# Altium schematic sheet payloadSheet2.SchDoc(payloadSheet2)
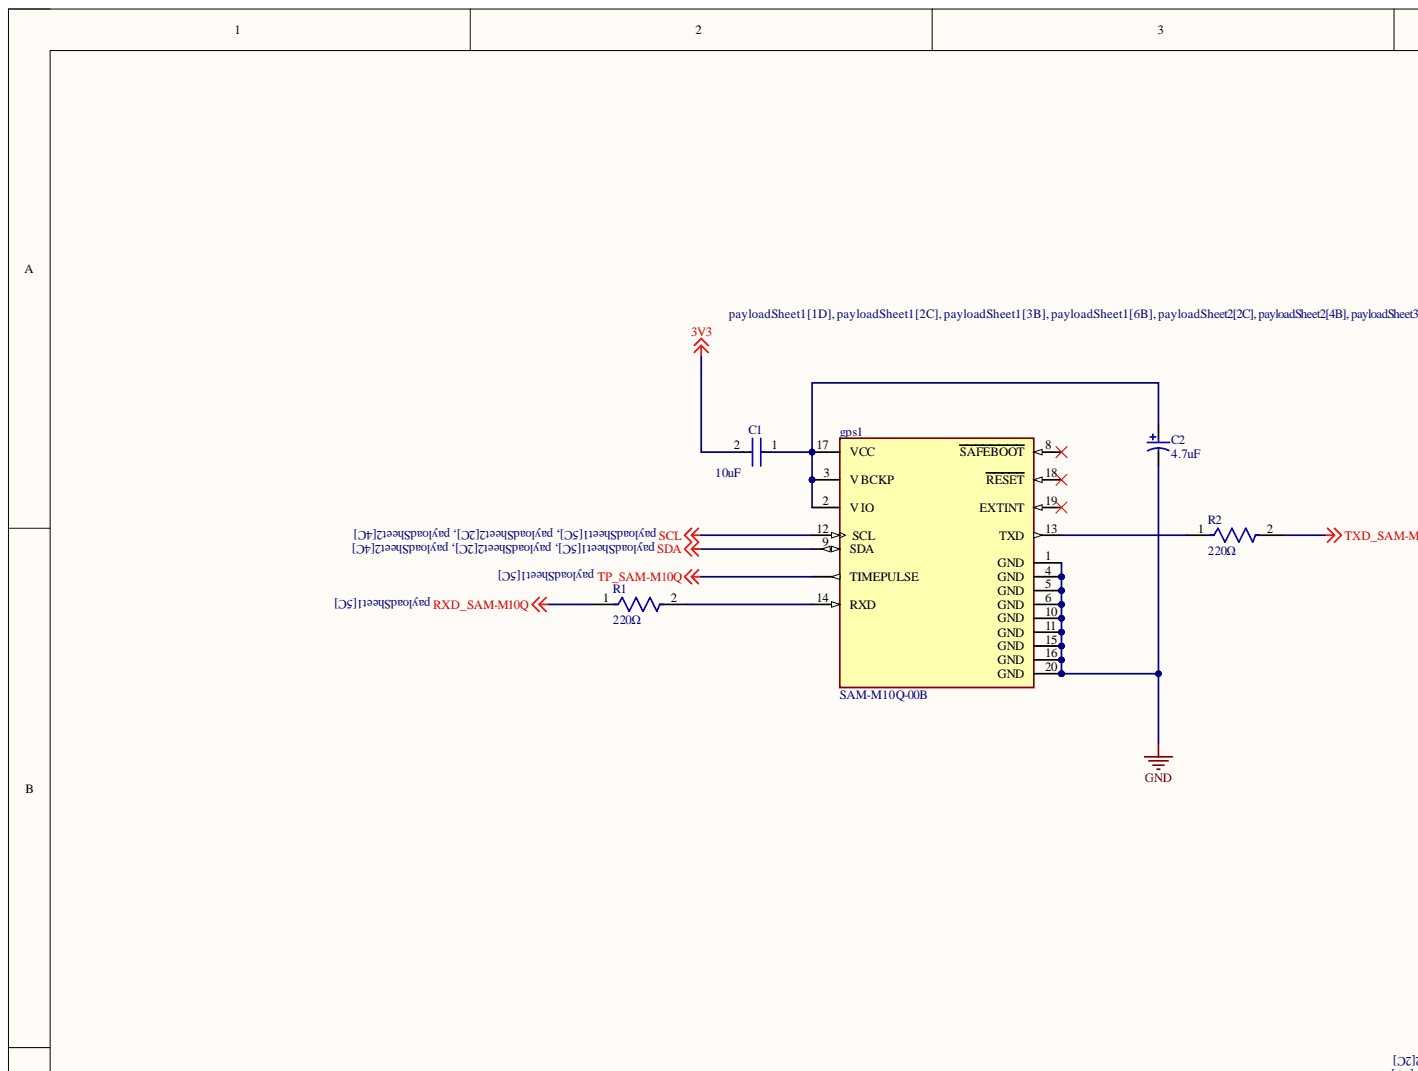
[diagram p0: payloadSheet2.SchDoc, top left]
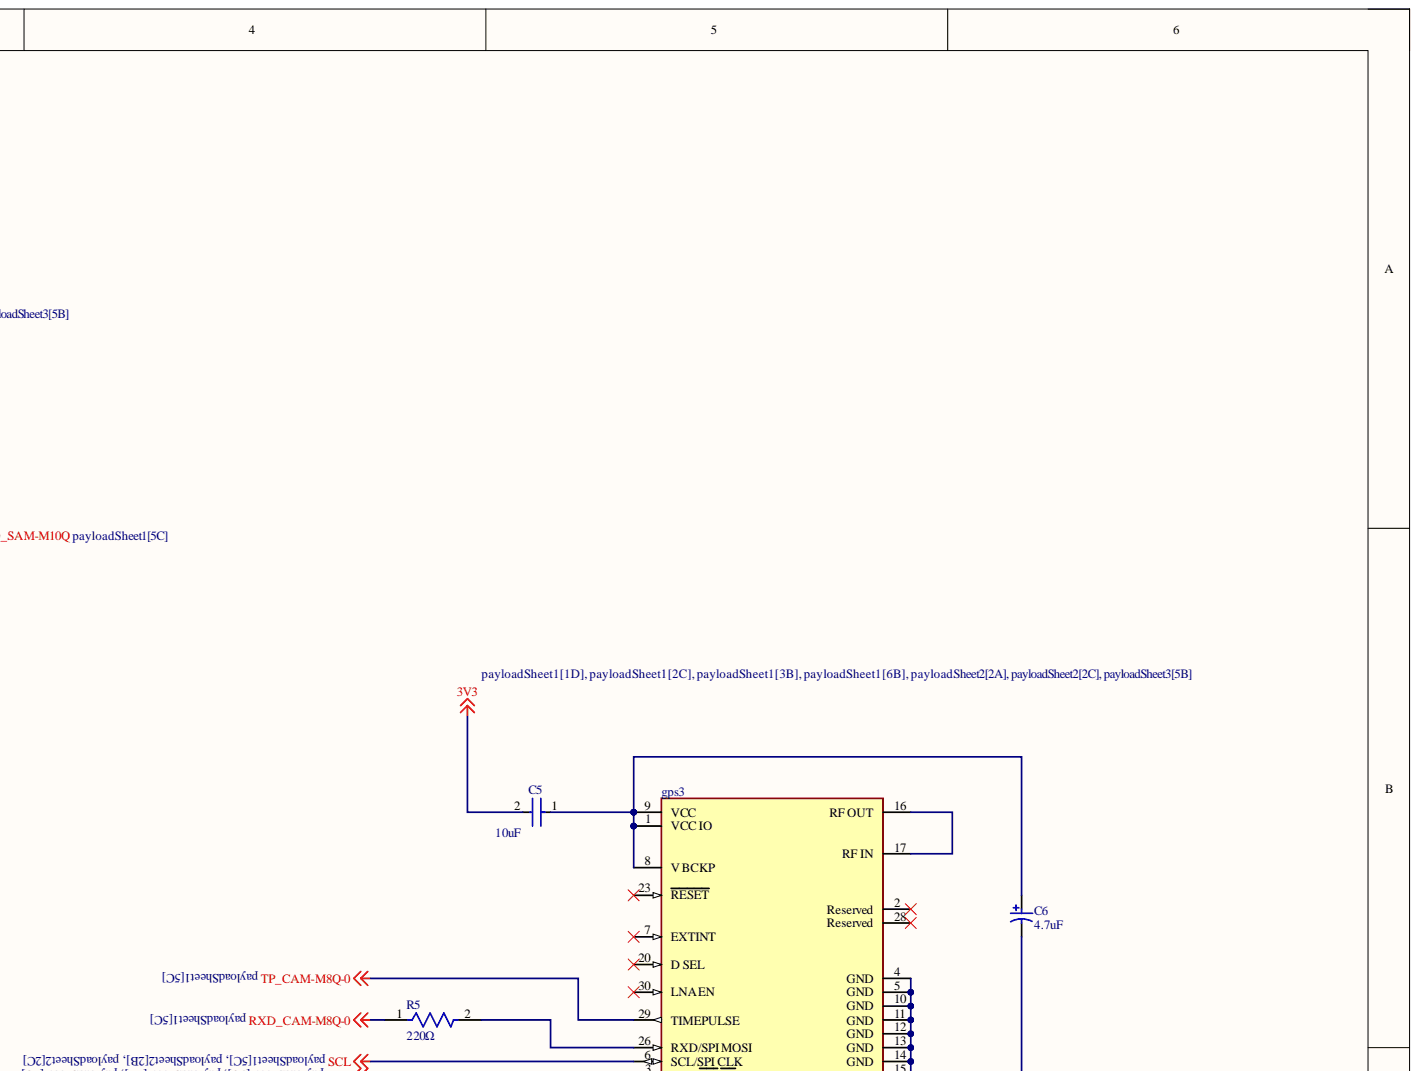
[diagram p1: payloadSheet2.SchDoc, top right]
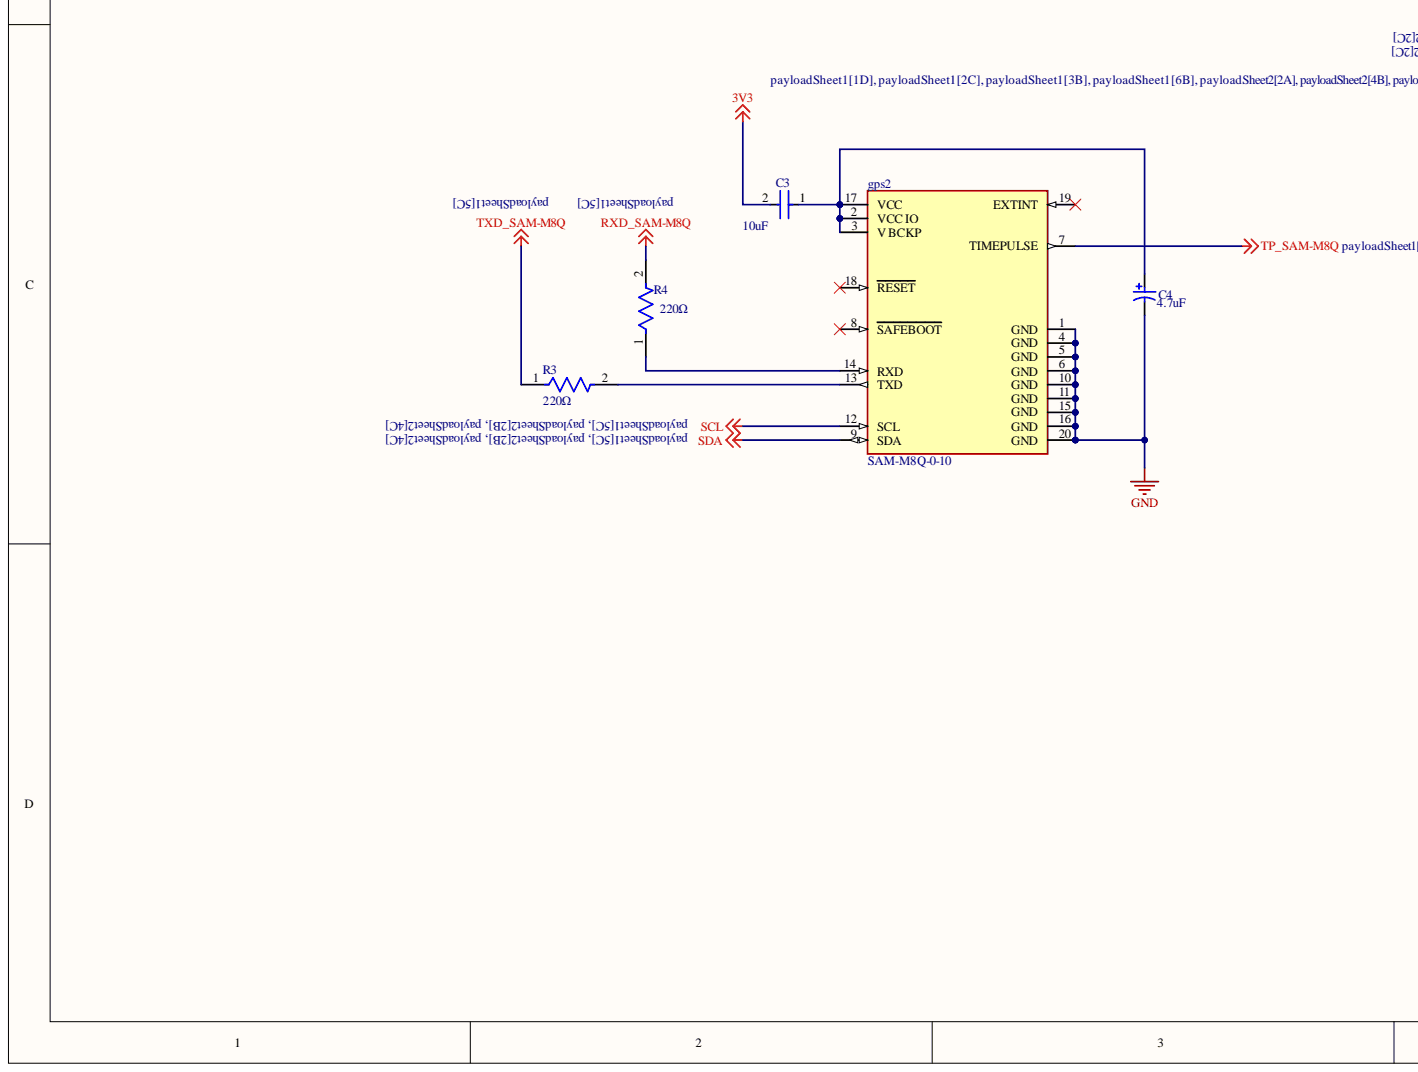
[diagram p2: payloadSheet2.SchDoc, bottom left]
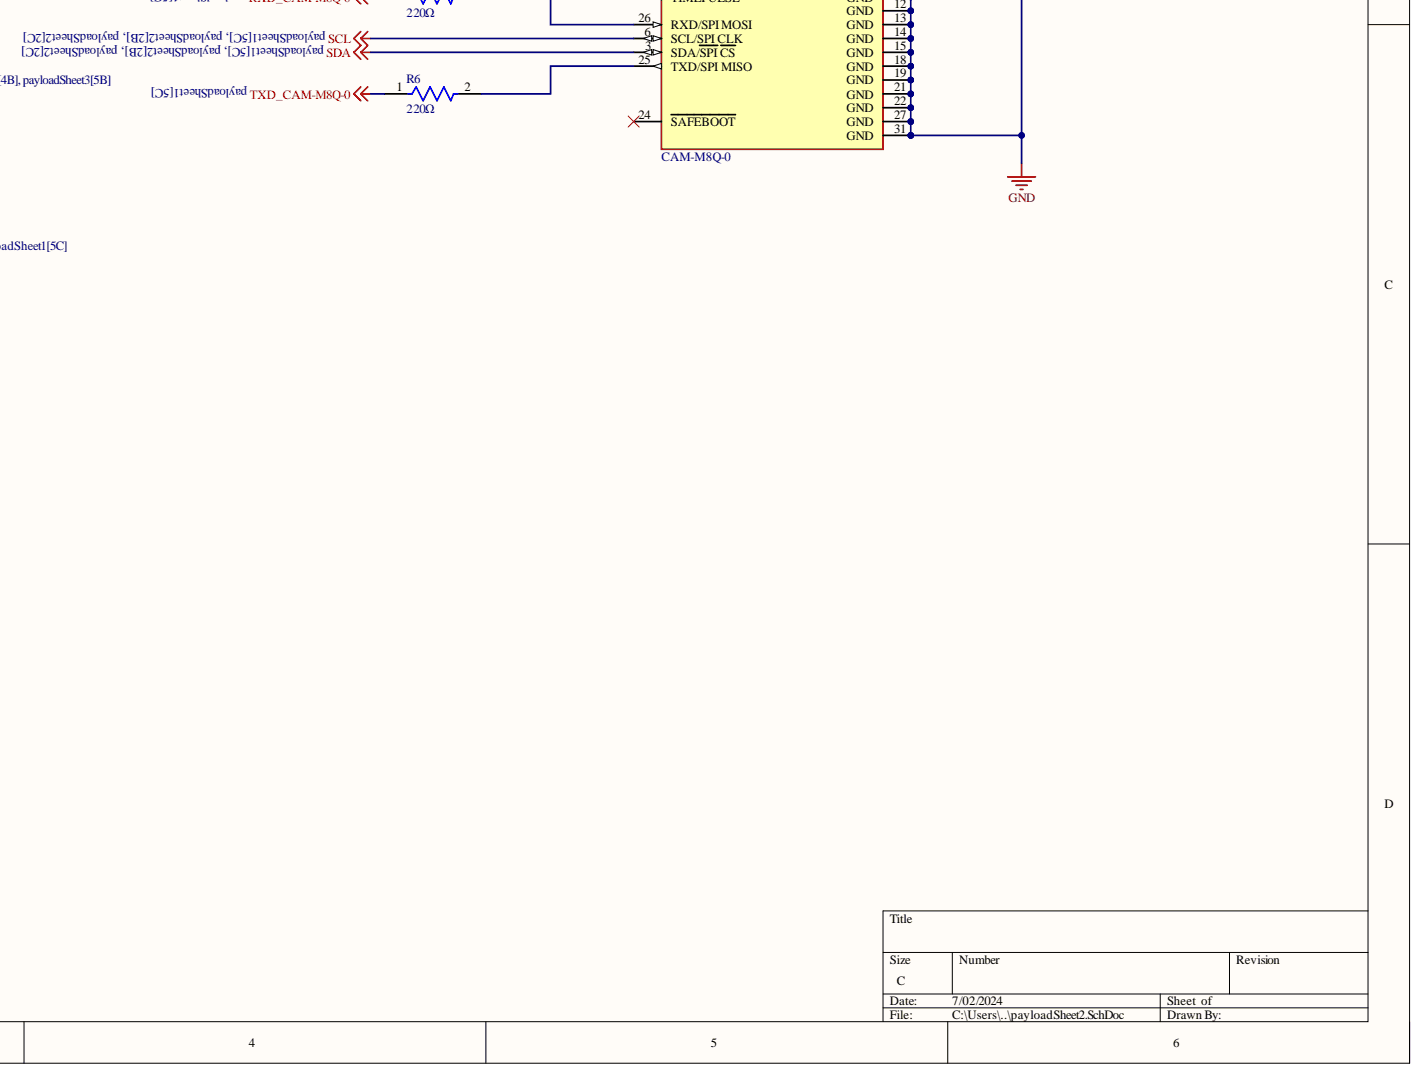
[diagram p3: payloadSheet2.SchDoc, bottom right]

## Parts
C1  10uF  [FP-RCE3DB-MFG]
C2  4.7uF  [AVX-TAP475K016SCS]
C3  10uF  [FP-RCE3DB-MFG]
C4  4.7uF  [AVX-TAP475K016SCS]
C5  10uF  [FP-RCE3DB-MFG]
C6  4.7uF  [AVX-TAP475K016SCS]
gps1  SAM-M10Q-00B  [FP-SAM-M10Q-00B-MFG]
gps2  SAM-M8Q-0-10  [FP-SAM-M8Q-0-10-MFG]
gps3  CAM-M8Q-0  [FP-CAM-M8Q-0-MFG]
R1  220Ω  [FP-MBA0204-MFG]
R2  220Ω  [FP-MBA0204-MFG]
R3  220Ω  [FP-MBA0204-MFG]
R4  220Ω  [FP-MBA0204-MFG]
R5  220Ω  [FP-MBA0204-MFG]
R6  220Ω  [FP-MBA0204-MFG]

## Nets
3V3: C1.2 C3.2 C5.2
GND: C2.2 C4.2 C6.2 gps1.1(GND) gps1.4(GND) gps1.5(GND) gps1.6(GND) gps1.10(GND) gps1.11(GND) gps1.15(GND) gps1.16(GND) gps1.20(GND) gps2.1(GND) gps2.4(GND) gps2.5(GND) gps2.6(GND) gps2.10(GND) gps2.11(GND) gps2.15(GND) gps2.16(GND) gps2.20(GND) gps3.4(GND) gps3.5(GND) gps3.10(GND) gps3.11(GND) gps3.12(GND) gps3.13(GND) gps3.14(GND) gps3.15(GND) gps3.18(GND) gps3.19(GND) gps3.21(GND) gps3.22(GND) gps3.27(GND) gps3.31(GND)
RXD_CAM-M8Q-0: R5.1
RXD_SAM-M8Q: R4.2
RXD_SAM-M10Q: R1.1
SCL: gps1.12(SCL) gps2.12(SCL) gps3.6(SCL/SPI_CLK)
SDA: gps1.9(SDA) gps2.9(SDA) gps3.3(SDA/SPI_CS)
TP_CAM-M8Q-0: gps3.29(TIMEPULSE)
TP_SAM-M8Q: gps2.7(TIMEPULSE)
TP_SAM-M10Q: gps1.7(TIMEPULSE)
TXD_CAM-M8Q-0: R6.1
TXD_SAM-M8Q: R3.1
TXD_SAM-M10Q: R2.2
NetC1_1: C1.1 C2.1 gps1.2(V_IO) gps1.3(V_BCKP) gps1.17(VCC)
NetC3_1: C3.1 C4.1 gps2.2(VCC_IO) gps2.3(V_BCKP) gps2.17(VCC)
NetC5_1: C5.1 C6.1 gps3.1(VCC_IO) gps3.8(V_BCKP) gps3.9(VCC)
Netgps1_13: gps1.13(TXD) R2.1
Netgps1_14: gps1.14(RXD) R1.2
Netgps2_13: gps2.13(TXD) R3.2
Netgps2_14: gps2.14(RXD) R4.1
Netgps3_16: gps3.16(RF_OUT) gps3.17(RF_IN)
Netgps3_25: gps3.25(TXD/SPI_MISO) R6.2
Netgps3_26: gps3.26(RXD/SPI_MOSI) R5.2

Not connected: gps1 8(SAFEBOOT) 18(RESET) 19(EXTINT); gps2 8(SAFEBOOT) 18(RESET) 19(EXTINT); gps3 2(Reserved) 7(EXTINT) 20(D_SEL) 23(RESET) 24(SAFEBOOT) 28(Reserved) 30(LNA_EN)

## Library data of the parts
C1 C3 C5 = CMP-06035-033894-1: Cap Ceramic 10uF 25V X7R ±10% Radial 2.5mm +125°C Automotive Bulk; Lead Diameter: 0.5mm; Lead/Base Style: Straight; Capacitance: 10uF; Case/Package: Radial; Component Kind: Standard; Dielectric: X7R; Height: 5mm; Height - Seated (Max): 0.197inch; Lead Pitch: 0.098inch; Length: 0.217inch; Library Name: Altium Content Vault; Max Operating Temperature: 125°C; Min Operating Temperature: -55°C; Mount: Through Hole; Package Quantity: 500; Packaging: Bulk; Ratings: AEC-Q200; Resistor Type: Ceramic; Termination: Radial; Tolerance: 10%; Voltage Rating: 25V; Voltage Rating (DC): 25V; Width: 0.157inch
C2 C4 C6 = CMP-2000-07562-1: Dipped Radial Capacitor, 4.7 uF, +/- 10%, 16 V, -55 to 125 degC, RoHS, Bulk; Manufacturer: AVX; Dielectric Material: Tantalum; Dissipation Factor: 6%; ESR (Equivalent Series Resistance): 5Ohm; Lead Diameter: 0.5mm; Leakage Current: 600nA; Life (Hours): 1000Hour; Polarity: Polar; Voltage: 16V; Capacitance: 4.7uF; Case\/Package: Dipped; Component Kind: Standard; Datasheet URL: http://datasheets.avx.com/tap.pdf; Datasheet Version: 08/2015; Diameter: 4.5mm; Height: 7.5mm; Height - Seated (Max): 0.295inch; Lead Free: LeadFree; Lead Pitch: 2.5mm; Length: 7.5mm; Library Name: Altium Content Vault; Manufacturer URL: http://www.avx.com/; Max Operating Temperature: 125degC; Min Operating Temperature: -55degC; Mount: PCB; Mounting Technology: ThroughHole; Number of Pins: 2; Package Description: 2-Pin Through Hole Device, Dia 4.5 mm, Height 7.5 mm; Package Quantity: 1000; Package Reference: TAP475K016SCS; Packaging: Bulk; Radiation Hardening: No; REACH SVHC: NoSVHC; RoHSCompliant: True; Termination: Radial; Tolerance: 10%; Voltage Rating: 16V; Voltage Rating (DC): 16V
gps1 = CMP-207130-000027-1: M10 GNSS LGA PATCH ANTENNA MOD; Component Kind: Standard; Library Name: Altium Content Vault
gps2 = CMP-207130-000004-3: RF RX GALILEO 1.575GHZ/1.602GHZ; Manufacturer: uBlox; Automotive Grade: Grade 3; Data Rate: 400kbits/s; Interface: I2C,UART,Other; Max Frequency: 1602MHz; Max Supply Voltage: 3.6V; Min Supply Voltage: 2.7V; Nominal Supply Current: 9.5-32mA; Sensitivity: -165dBm; Automotive: Yes; Category: IC; Component Kind: Standard; ComponentLink2Description: Datasheet; ComponentLink2URL: https://www.u-blox.com/sites/default/files/SAM-M8Q_DataSheet_%28UBX-16012619%29.pdf; Device Class L1: Integrated Circuits (ICs); Device Class L2: RF Semiconductors and Devices; Device Class L3: Transceivers; Digikey Description: RF RX GALILEO 1.575GHZ/1.602GHZ; Footprint Url: https://www.u-blox.com/sites/default/files/SAM-M8Q_HardwareIntegrationManual_%28UBX-16018358%29.pdf; Height: 6.8mm; Lead Free: Yes; Library Name: Altium Content Vault; Manufacturer Part Number: SAM-M8Q-0-10; Octopart: https://octopart.com/sam-m8q-0-10-u-blox-88247750; Package: 0; RoHS: TRUE; Temperature: -40°C to +85°C; Temperature Range High: +85°C; Temperature Range Low: -40°C
gps3 = CMP-207130-000001-1: RF RCVR GNSS/GPS 1.575GHZ MODULE; Manufacturer: u-blox; Automotive Grade: NA; Data Rate: 400kbits/s; Interface: I2C,SPI,UART; Max Frequency: 1602MHz; Max Supply Voltage: 3.6V; Min Supply Voltage: 1.4V; Nominal Supply Current: 10.4-30mA; Sensitivity: -138dBm; Automotive: No; Category: UNK; Component Kind: Standard; ComponentLink2Description: Datasheet; ComponentLink2URL: https://www.u-blox.com/sites/default/files/CAM-M8-FW3_DataSheet_%28UBX-15031574%29.pdf; Device Class L1: Integrated Circuits (ICs); Device Class L2: RF Semiconductors and Devices; Device Class L3: RF Receivers; Digikey Description: RF RCVR GNSS/GPS 1.575GHZ MODULE; Footprint Url: https://www.u-blox.com/sites/default/files/CAM-M8-FW3_HardwareIntegrationManual_%28UBX-15030063%29.pdf; Height: 2.3mm; Lead Free: Yes; Library Name: Altium Content Vault; Manufacturer Part Number: CAM-M8Q-0; Octopart: https://octopart.com/cam-m8q-0-u-blox-54356730; Package: CAM-M8Q-0; RoHS: TRUE; Temperature: -40°C to +85°C; Temperature Range High: +85°C; Temperature Range Low: -40°C
R1-R6 = CMP-02408-000005-1: RES 220 OHM 0.4W 1% AXIAL; Manufacturer: Vishay BC Components; Manufacturer Part Number: MBA02040C2200FC100; Automotive Grade: Grade 1; Power Rating: 0.25W; Temperature Coefficient: 50ppm/°C; Voltage Rating: 200V; Automotive: Yes; Category: Res; Component Kind: Standard; ComponentLink2Description: Datasheet; ComponentLink2URL: http://www.vishay.com/docs/28766/mbxsma.pdf; Composition: Metal Film; Device Class L1: Passive Components; Device Class L2: Resistors; Device Class L3: Through-Hole Resistors; Digikey Description: RES 220 OHM 0.4W 1% AXIAL; Height: 1.6mm; Ipc Land Pattern Name: RESAD500W65L360D160; Lead Free: Yes; Library Name: Altium Content Vault; Mouser Description: Thin Film Resistors - Through Hole .4watt 220ohms 1% 1/8watt body size; Octopart: https://octopart.com/mba02040c2200fc100-vishay-43743094; Package: MBA0204; Resistance: 220Ω; RoHS: TRUE; Series: MBA/SMA 0204; Temperature: -55°C to +125°C; Temperature Range High: +125°C; Temperature Range Low: -55°C; Tolerance: 1%; Value: 220Ω
